# Altium schematic sheet BM20_Rev0_VC_CB.SchDoc
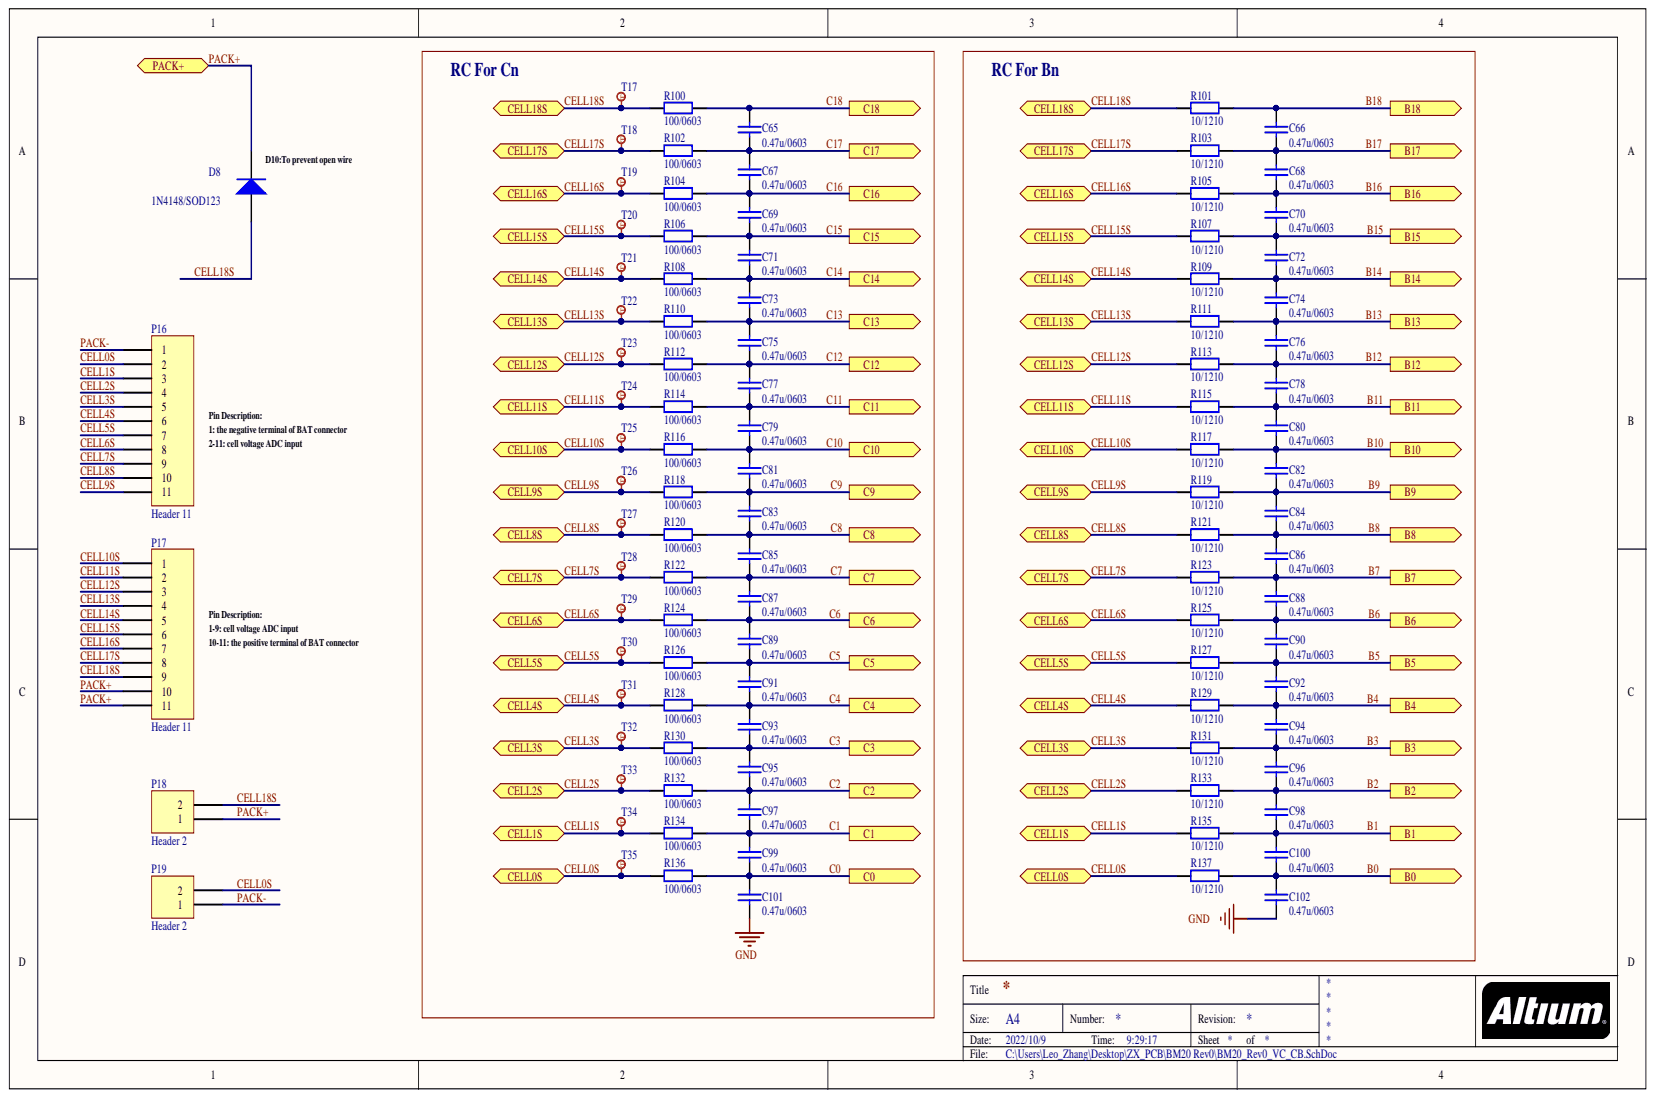
[diagram p0: BM20_Rev0_VC_CB.SchDoc]

## Parts
C65  0.47u/0603  [0603_C]
C66  0.47u/0603  [0603_C]
C67  0.47u/0603  [0603_C]
C68  0.47u/0603  [0603_C]
C69  0.47u/0603  [0603_C]
C70  0.47u/0603  [0603_C]
C71  0.47u/0603  [0603_C]
C72  0.47u/0603  [0603_C]
C73  0.47u/0603  [0603_C]
C74  0.47u/0603  [0603_C]
C75  0.47u/0603  [0603_C]
C76  0.47u/0603  [0603_C]
C77  0.47u/0603  [0603_C]
C78  0.47u/0603  [0603_C]
C79  0.47u/0603  [0603_C]
C80  0.47u/0603  [0603_C]
C81  0.47u/0603  [0603_C]
C82  0.47u/0603  [0603_C]
C83  0.47u/0603  [0603_C]
C84  0.47u/0603  [0603_C]
C85  0.47u/0603  [0603_C]
C86  0.47u/0603  [0603_C]
C87  0.47u/0603  [0603_C]
C88  0.47u/0603  [0603_C]
C89  0.47u/0603  [0603_C]
C90  0.47u/0603  [0603_C]
C91  0.47u/0603  [0603_C]
C92  0.47u/0603  [0603_C]
C93  0.47u/0603  [0603_C]
C94  0.47u/0603  [0603_C]
C95  0.47u/0603  [0603_C]
C96  0.47u/0603  [0603_C]
C97  0.47u/0603  [0603_C]
C98  0.47u/0603  [0603_C]
C99  0.47u/0603  [0603_C]
C100  0.47u/0603  [0603_C]
C101  0.47u/0603  [0603_C]
C102  0.47u/0603  [0603_C]
D8  1N4148/SOD123  [SOD-123]
P16  Header 11  [MX3.0mm-11P]
P17  Header 11  [MX3.0mm-11P]
P18  Header 2  [HDR1X2]
P19  Header 2  [HDR1X2]
R100  100/0603  [0603_R]
R101  10/1210  [1210_R]
R102  100/0603  [0603_R]
R103  10/1210  [1210_R]
R104  100/0603  [0603_R]
R105  10/1210  [1210_R]
R106  100/0603  [0603_R]
R107  10/1210  [1210_R]
R108  100/0603  [0603_R]
R109  10/1210  [1210_R]
R110  100/0603  [0603_R]
R111  10/1210  [1210_R]
R112  100/0603  [0603_R]
R113  10/1210  [1210_R]
R114  100/0603  [0603_R]
R115  10/1210  [1210_R]
R116  100/0603  [0603_R]
R117  10/1210  [1210_R]
R118  100/0603  [0603_R]
R119  10/1210  [1210_R]
R120  100/0603  [0603_R]
R121  10/1210  [1210_R]
R122  100/0603  [0603_R]
R123  10/1210  [1210_R]
R124  100/0603  [0603_R]
R125  10/1210  [1210_R]
R126  100/0603  [0603_R]
R127  10/1210  [1210_R]
R128  100/0603  [0603_R]
R129  10/1210  [1210_R]
R130  100/0603  [0603_R]
R131  10/1210  [1210_R]
R132  100/0603  [0603_R]
R133  10/1210  [1210_R]
R134  100/0603  [0603_R]
R135  10/1210  [1210_R]
R136  100/0603  [0603_R]
R137  10/1210  [1210_R]
T17  Test  [TP_Point]  (value not drawn)
T18  Test  [TP_Point]  (value not drawn)
T19  Test  [TP_Point]  (value not drawn)
T20  Test  [TP_Point]  (value not drawn)
T21  Test  [TP_Point]  (value not drawn)
T22  Test  [TP_Point]  (value not drawn)
T23  Test  [TP_Point]  (value not drawn)
T24  Test  [TP_Point]  (value not drawn)
T25  Test  [TP_Point]  (value not drawn)
T26  Test  [TP_Point]  (value not drawn)
T27  Test  [TP_Point]  (value not drawn)
T28  Test  [TP_Point]  (value not drawn)
T29  Test  [TP_Point]  (value not drawn)
T30  Test  [TP_Point]  (value not drawn)
T31  Test  [TP_Point]  (value not drawn)
T32  Test  [TP_Point]  (value not drawn)
T33  Test  [TP_Point]  (value not drawn)
T34  Test  [TP_Point]  (value not drawn)
T35  Test  [TP_Point]  (value not drawn)

## Ports
B0, B1, B2, B3, B4, B5, B6, B7, B8, B9, B10, B11, B12, B13, B14, B15, B16, B17, B18, C0, C1, C2, C3, C4, C5, C6, C7, C8, C9, C10, C11, C12, C13, C14, C15, C16, C17, C18, CELL0S, CELL1S, CELL2S, CELL3S, CELL4S, CELL5S, CELL6S, CELL7S, CELL8S, CELL9S, CELL10S, CELL11S, CELL12S, CELL13S, CELL14S, CELL15S, CELL16S, CELL17S, CELL18S, PACK+

## Nets
GND: C101.1 C102.1
B0: C100.1 C102.2 R137.2 ; port B0
B1: C98.1 C100.2 R135.2 ; port B1
B2: C96.1 C98.2 R133.2 ; port B2
B3: C94.1 C96.2 R131.2 ; port B3
B4: C92.1 C94.2 R129.2 ; port B4
B5: C90.1 C92.2 R127.2 ; port B5
B6: C88.1 C90.2 R125.2 ; port B6
B7: C86.1 C88.2 R123.2 ; port B7
B8: C84.1 C86.2 R121.2 ; port B8
B9: C82.1 C84.2 R119.2 ; port B9
B10: C80.1 C82.2 R117.2 ; port B10
B11: C78.1 C80.2 R115.2 ; port B11
B12: C76.1 C78.2 R113.2 ; port B12
B13: C74.1 C76.2 R111.2 ; port B13
B14: C72.1 C74.2 R109.2 ; port B14
B15: C70.1 C72.2 R107.2 ; port B15
B16: C68.1 C70.2 R105.2 ; port B16
B17: C66.1 C68.2 R103.2 ; port B17
B18: C66.2 R101.2 ; port B18
C0: C99.1 C101.2 R136.2 ; port C0
C1: C97.1 C99.2 R134.2 ; port C1
C2: C95.1 C97.2 R132.2 ; port C2
C3: C93.1 C95.2 R130.2 ; port C3
C4: C91.1 C93.2 R128.2 ; port C4
C5: C89.1 C91.2 R126.2 ; port C5
C6: C87.1 C89.2 R124.2 ; port C6
C7: C85.1 C87.2 R122.2 ; port C7
C8: C83.1 C85.2 R120.2 ; port C8
C9: C81.1 C83.2 R118.2 ; port C9
C10: C79.1 C81.2 R116.2 ; port C10
C11: C77.1 C79.2 R114.2 ; port C11
C12: C75.1 C77.2 R112.2 ; port C12
C13: C73.1 C75.2 R110.2 ; port C13
C14: C71.1 C73.2 R108.2 ; port C14
C15: C69.1 C71.2 R106.2 ; port C15
C16: C67.1 C69.2 R104.2 ; port C16
C17: C65.1 C67.2 R102.2 ; port C17
C18: C65.2 R100.2 ; port C18
CELL0S: P16.2 P19.2 R136.1 R137.1 T35.0 ; port CELL0S
CELL1S: P16.3 R134.1 R135.1 T34.0 ; port CELL1S
CELL2S: P16.4 R132.1 R133.1 T33.0 ; port CELL2S
CELL3S: P16.5 R130.1 R131.1 T32.0 ; port CELL3S
CELL4S: P16.6 R128.1 R129.1 T31.0 ; port CELL4S
CELL5S: P16.7 R126.1 R127.1 T30.0 ; port CELL5S
CELL6S: P16.8 R124.1 R125.1 T29.0 ; port CELL6S
CELL7S: P16.9 R122.1 R123.1 T28.0 ; port CELL7S
CELL8S: P16.10 R120.1 R121.1 T27.0 ; port CELL8S
CELL9S: P16.11 R118.1 R119.1 T26.0 ; port CELL9S
CELL10S: P17.1 R116.1 R117.1 T25.0 ; port CELL10S
CELL11S: P17.2 R114.1 R115.1 T24.0 ; port CELL11S
CELL12S: P17.3 R112.1 R113.1 T23.0 ; port CELL12S
CELL13S: P17.4 R110.1 R111.1 T22.0 ; port CELL13S
CELL14S: P17.5 R108.1 R109.1 T21.0 ; port CELL14S
CELL15S: P17.6 R106.1 R107.1 T20.0 ; port CELL15S
CELL16S: P17.7 R104.1 R105.1 T19.0 ; port CELL16S
CELL17S: P17.8 R102.1 R103.1 T18.0 ; port CELL17S
CELL18S: D8.1 P17.9 P18.2 R100.1 R101.1 T17.0 ; port CELL18S
PACK+: D8.2 P17.10 P17.11 P18.1 ; port PACK+
PACK-: P16.1 P19.1

## Library data of the parts
C65-C102 = capacitor: 贴片电容; Component Kind: Standard; Library Name: Schlib1.SchLib
D8 = Diode: Default Diode; Code_JEDEC: DO-214-AB; Component Kind: Standard; LatestRevisionDate: 17-Jul-2002; LatestRevisionNote: Re-released for DXP Platform.; Library Name: BM02 Rev0.SchLib; PackageReference: SMC; PackageVersion: Sep-1996; Published: 8-Jun-2000; Signal Integrity: Diode; Simulation: DIODE; Publisher: Altium Limited
P16 P17 = Header 11: Header, 11-Pin; Component Kind: Standard; LatestRevisionDate: 17-Jul-2002; LatestRevisionNote: Re-released for DXP Platform.; Library Name: Miscellaneous Connectors.IntLib; Signal Integrity: Connector; Publisher: Altium Limited
P18 P19 = Header 2: Header, 2-Pin; Component Kind: Standard; Library Name: Miscellaneous Connectors.IntLib; Signal Integrity: Connector
R100-R137 = Resistor: 贴片电阻; Component Kind: Standard; Library Name: Schlib1.SchLib
T17-T35 = Test: Component Kind: Standard; Library Name: 17S.SchLib
